# Altium schematic sheet ESP32-HWE-Dev-SPI.SchDoc(ESP32-HWE-Dev-SPI)
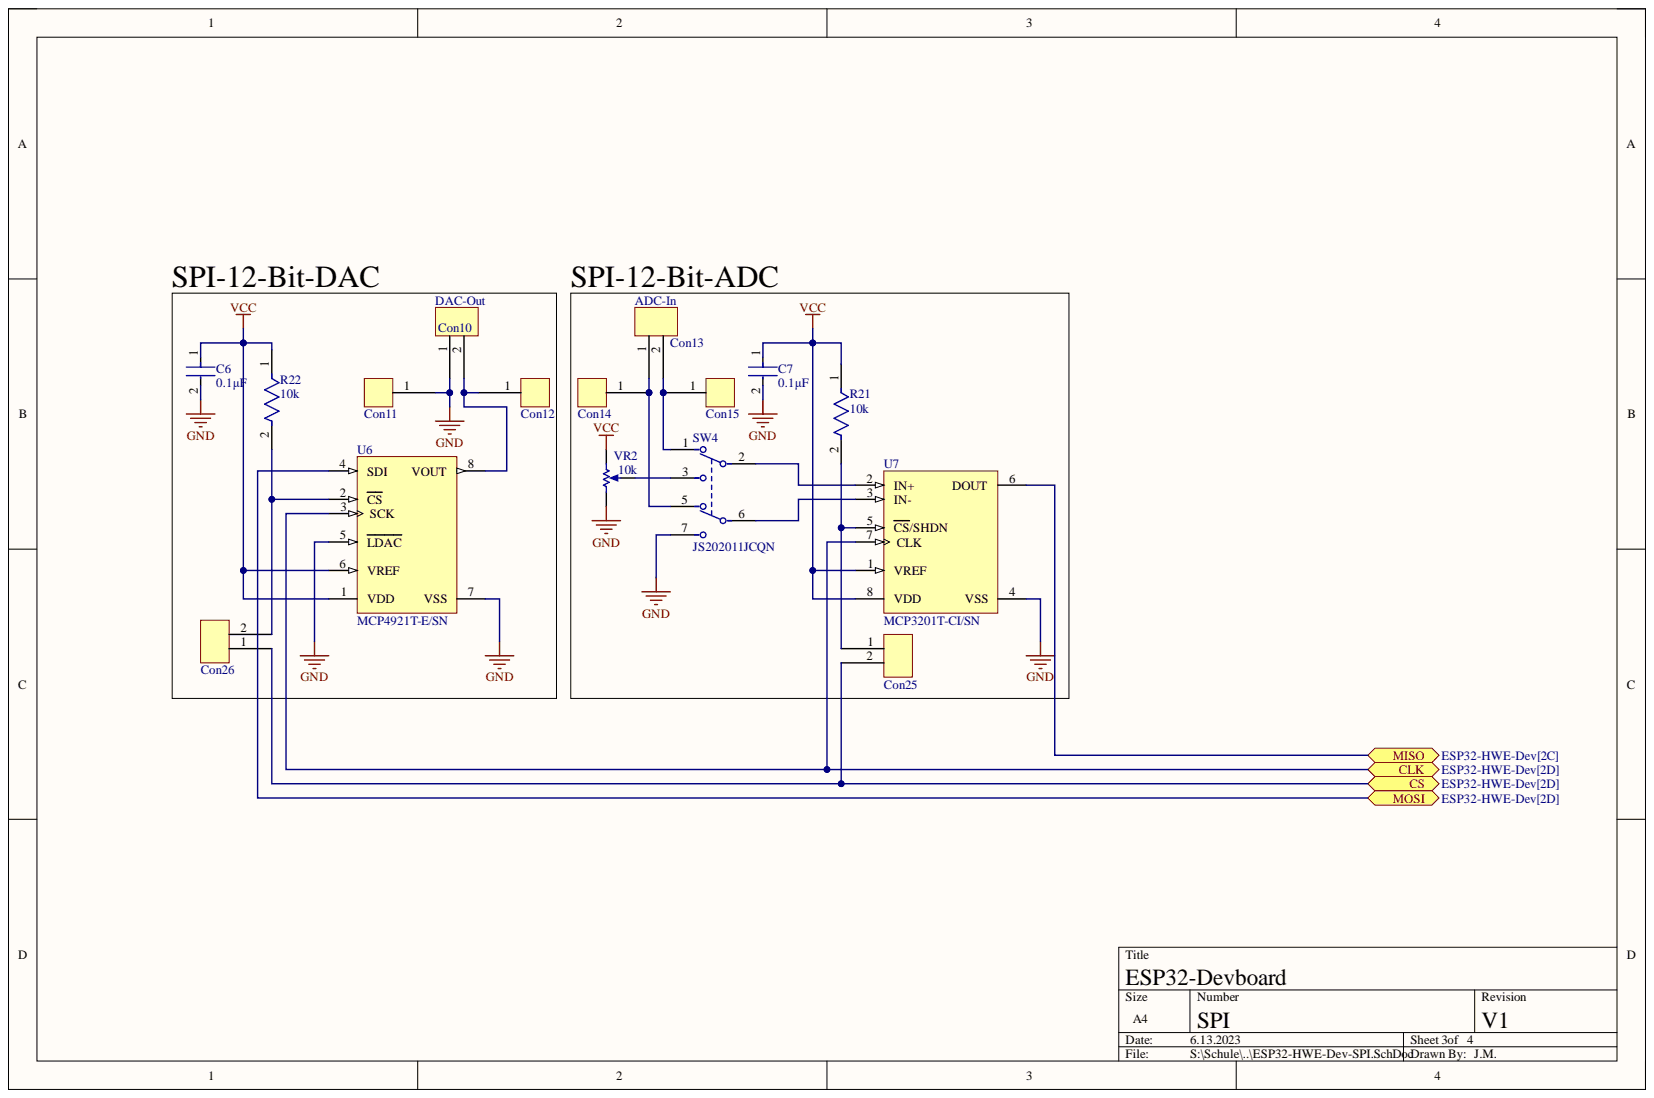
[diagram p0: ESP32-HWE-Dev-SPI.SchDoc]

## Parts
C6  0.1µF  [FP-0805-L_2_01_0_2-W_1_25-IPC_C]
C7  0.1µF  [FP-0805-L_2_01_0_2-W_1_25-IPC_C]
Con10  DAC-Out  [Header_Female_2Pin]
Con11  Solder Nail RTM 1.3  [Solder_Nail_RTM_1.3]  (value not drawn)
Con12  Solder Nail RTM 1.3  [Solder_Nail_RTM_1.3]  (value not drawn)
Con13  ADC-In  [Header_Female_2Pin]
Con14  Solder Nail RTM 1.3  [Solder_Nail_RTM_1.3]  (value not drawn)
Con15  Solder Nail RTM 1.3  [Solder_Nail_RTM_1.3]  (value not drawn)
Con25  Male 2 Pin  [Header_Male_2Pin]  (value not drawn)
Con26  Male 2 Pin  [Header_Male_2Pin]  (value not drawn)
R21  10k  [FP-AC0805-MFG]
R22  10k  [FP-AC0805-MFG]
SW4  JS202011JCQN  [FP-JS202011JCQN-MFG]
U6  MCP4921T-E/SN  [SOIC-SN8_L]
U7  MCP3201T-CI/SN  [SOIC-SN8_M]
VR2  10k  [BOUR-3362P]

## Ports
CLK, CS, MISO, MOSI

## Nets
GND: C6.2 C7.2 Con10.1 Con11.1 SW4.7 U6.5(LDAC) U6.7(VSS) U7.4(VSS) VR2.1
VCC: C6.1 C7.1 R21.1 R22.1 U6.1(VDD) U6.6(VREF) U7.1(VREF) U7.8(VDD) VR2.3
CLK: U6.3(SCK) U7.7(CLK) ; port CLK
CS: Con25.2 Con26.1 ; port CS
MISO: U7.6(DOUT) ; port MISO
MOSI: U6.4(SDI) ; port MOSI
NetCon10_2: Con10.2 Con12.1 U6.8(VOUT)
NetCon13_1: Con13.1 Con14.1 SW4.5
NetCon13_2: Con13.2 Con15.1 SW4.1
NetCon25_1: Con25.1 R21.2 U7.5(CS/SHDN)
NetCon26_2: Con26.2 R22.2 U6.2(CS)
NetSW4_2: SW4.2 U7.2(IN+)
NetSW4_3: SW4.3 VR2.2
NetSW4_6: SW4.6 U7.3(IN-)

## Library data of the parts
C6 C7 = CMP-2000-05016-2: General Purpose Ceramic Capacitor, 0805, 100nF, 10%, X7R, 0.15, 50V; Dissipation Factor: 2.5%; Insulation Resistance: 100GR; Capacitance: 100nF; Case Code (Imperial): 0805; Case Code (Metric): 2012; Case/Package: 0805; Component Kind: Standard; Depth: 1.25mm; Dielectric: X7R; Dielectric Material: Ceramic; Height: 0.5mm; Lead Free: Lead Free; Length: 2mm; Library Name: Altium Content Vault; Max Operating Temperature: 125°C; Min Operating Temperature: -55°C; Mount: Surface Mount; Number of Pins: 2; Package Quantity: 4000; Packaging: Cut Tape; Radiation Hardening: No; REACH SVHC: No SVHC; RoHS Compliant: Yes; Series: MLCC X7R; Termination: Standard; Thickness: 0.037inch; Tolerance: 10%; Voltage: 50V; Voltage Rating: 50V; Voltage Rating (DC): 50V; Weight: 0.001411oz; Width: 1.2mm
Con10 Con13 = Header_Female_2Pin: Component Kind: Standard; Library Name: ESP32-HWE-Dev.SchLib
Con11 Con12 Con14 Con15 = Solder_Nail_RTM_1.3: Component Kind: Standard; Library Name: ESP32-HWE-Dev.SchLib
Con25 Con26 = Header_Male_2Pin: Component Kind: Standard; Library Name: ESP32-HWE-Dev.SchLib
R21 R22 = CMP-2001-04476-2: RES SMD 4.7K OHM 5% 1/8W 0805; Manufacturer: Yageo; Manufacturer Part Number: AC0805JR-074K7L; Automotive Grade: Grade 0; Resistance: 4.7KΩ; Tolerance: 0.05; Value: 4.7KΩ; Voltage Rating: 150V; Automotive: Yes; Category: Res; Component Kind: Standard; ComponentLink2Description: Datasheet; ComponentLink2URL: http://www.yageo.com/documents/recent/PYu-AC_51_RoHS_L_6.pdf; Composition: Thick Film; Device Class L1: Passive Components; Device Class L2: Resistors; Device Class L3: Chip SMD Resistors; Digikey Description: RES SMD 4.7K OHM 5% 1/8W 0805; Footprint Url: http://www.yageo.com/exep/pages/download/literatures/PYu-R_Mount_10.pdf; Height: 0.6mm; Ipc Land Pattern Name: RESC200125X50; Lead Free: Yes; Library Name: Altium Content Vault; Mouser Description: Thick Film Resistors - SMD 1/8W 4.7K ohm 5% AEC-Q200; Octopart: https://octopart.com/ac0805jr-074k7l-yageo-26638632; Package: AC0805; Power Rating: 1/8W; RoHS: TRUE; Series: AC; Temperature: -55°C to +155°C; Temperature Range High: +155°C; Temperature Range Low: -55°C
SW4 = CMP-10038-000009-1: SWITCH SLIDE DPDT 300MA 6V; Actuator Length: 2mm; Actuator Material: Nylon; Actuator Type: Standard; Electrical Life: 5000Cycles; Switch Function: On-On; Component Kind: Standard; Contact Material: Copper Alloy; Contact Plating: Silver; Current Rating: 300mA; Library Name: Altium Content Vault; Max Operating Temperature: 85°C; Min Operating Temperature: -40°C; Mount: Surface Mount; Packaging: Cut Tape; Switch Travel: 2mm; Throw Configuration: DPDT; Voltage Rating (DC): 6V
U6 = CMP-0188-00494-2: 12-Bit DAC with SPI Interface, 8-Pin SOIC 150mil, Extended Temperature, Tape and Reel; Code_IPC: SOIC127P600-8; Code_JEDEC: MS-012; Component Kind: Standard; ComponentLink1Description: Manufacturer URL; ComponentLink1URL: http://www.microchip.com/; ComponentLink2Description: Datasheet; ComponentLink2URL: http://ww1.microchip.com/downloads/en/DeviceDoc/22248a.pdf; ComponentLink3Description: Package Specification; ComponentLink3URL: http://www.microchip.com/stellent/groups/techpub_sg/documents/packagingspec/en012702.pdf; DatasheetVersion: revA, Apr-2010; Library Name: Altium Content Vault; PackageDescription: 8-Lead Plastic Small Outline (SN) - Narrow, 3.90mm Body [SOIC]; PackageReference: SOIC-SN8; PackageVersion: revBB, Aug-2009; RevisionNote: URLs added. DatasheetVersion updated. Sch updated.
U7 = CMP-0188-00467-2: 2.7V 12-Bit A/D Converter with SPI Serial Interface, 8-Pin SOIC 150mil, C Performance Grade, Industrial Temperature, Tape and Reel; Code_IPC: SOIC127P600-8; Code_JEDEC: MS-012; Component Kind: Standard; ComponentLink1Description: Manufacturer URL; ComponentLink1URL: http://www.microchip.com/; ComponentLink2Description: Datasheet; ComponentLink2URL: http://ww1.microchip.com/downloads/en/DeviceDoc/21290F.pdf; ComponentLink3Description: Package Specification; ComponentLink3URL: http://www.microchip.com/stellent/groups/techpub_sg/documents/packagingspec/en012702.pdf; DatasheetVersion: revF, Aug-2011; Library Name: Altium Content Vault; PackageDescription: 8-Lead Plastic Small Outline (SN) - Narrow, 3.90mm Body [SOIC]; PackageReference: SOIC-SN8; PackageVersion: revBB, Aug-2009; RevisionNote: URLs added. DatasheetVersion updated.
VR2 = CMP-1747-00004-1: Square Trimpot(R) Trimming Potentiometer, 10 KOhm, 300 V, -55 to 125 degC, 3-Pin THD, RoHS, Tube; Power Rating: 1 W; Resistance: 50 mohm; Tolerance: 1%; Voltage Rating: 220 mV; Case Code (Imperial): 0612; Case Code (Metric): 1632; Case/Package: 1206; Component Kind: Standard; ComponentLink1Description: Manufacturer URL; ComponentLink1URL: http://www.bourns.com/; ComponentLink2Description: Datasheet; ComponentLink2URL: http://www.bourns.com/docs/Product-Datasheets/3362.pdf; DatasheetVersion: May-16; Height: 0.6 mm; Length: 3.2 mm; Library Name: Altium Content Vault; Max Operating Temperature: 125degC; Min Operating Temperature: -25degC; Mount: Surface Mount; Package Quantity: 5000; PackageDescription: 3-Pin Through Hole Device, Body 6.6 x 6.99 mm, Pitch 2.54 mm; PackageReference: 3326P; Packaging: Tape and Reel; Pins: 2; REACH SVHC: No SVHC; RoHSCompliant: True; Width: 1.6 mm
